# Altium schematic sheet mcu.SchDoc
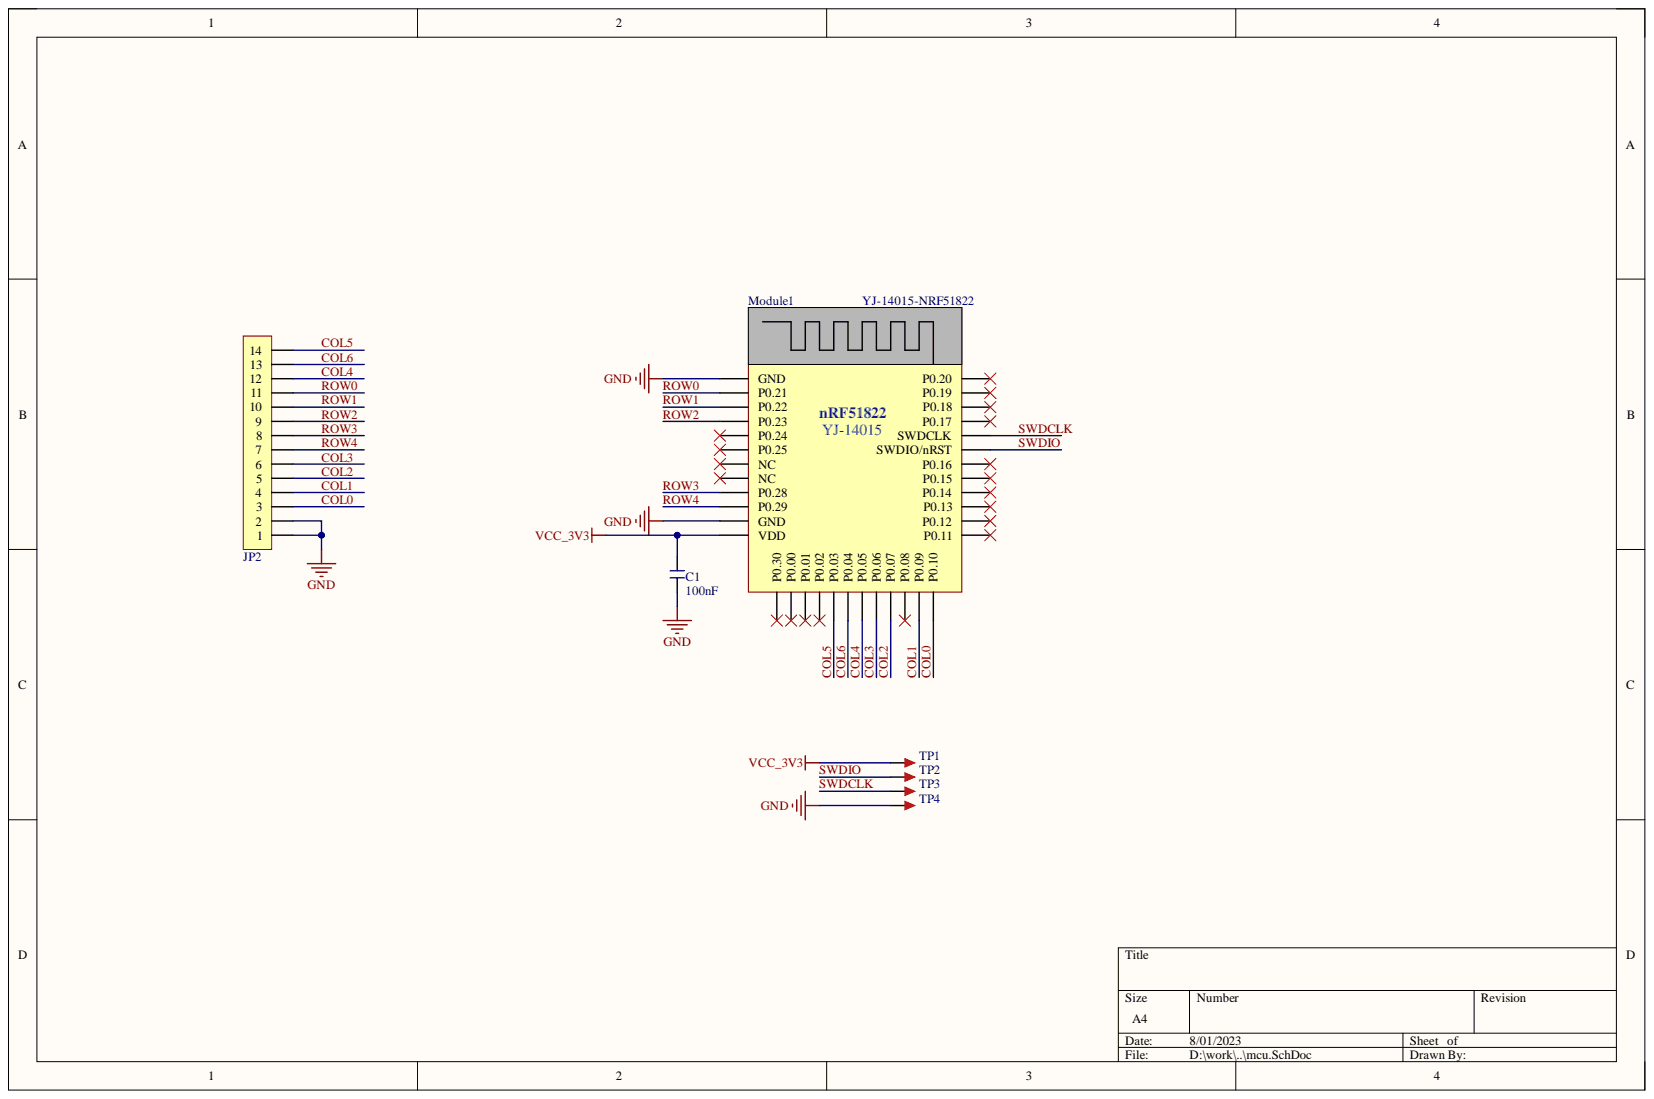
[diagram p0: mcu.SchDoc]

## Parts
C1  100nF  [C 0402]
JP2  FPC1.0-14P  [FPC0.5 2H-S-W-14P]  (value not drawn)
Module1  YJ-14015-NRF51822  [YJ-14015-NRF51822]
TP1  TEST  [TEST 1.8]  (value not drawn)
TP2  TEST  [TEST 1.8]  (value not drawn)
TP3  TEST  [TEST 1.8]  (value not drawn)
TP4  TEST  [TEST 1.8]  (value not drawn)

## Nets
GND: C1.1 JP2.1 JP2.2 Module1.1(GND) Module1.11(GND) TP4.1
VCC_3V3: C1.2 Module1.12(VDD) TP1.1
COL0: JP2.3 Module1.24(P0.10)
COL1: JP2.4 Module1.23(P0.09)
COL2: JP2.5 Module1.21(P0.07)
COL3: JP2.6 Module1.20(P0.06)
COL4: JP2.12 Module1.19(P0.05)
COL5: JP2.14 Module1.17(P0.03)
COL6: JP2.13 Module1.18(P0.04)
ROW0: JP2.11 Module1.2(P0.21)
ROW1: JP2.10 Module1.3(P0.22)
ROW2: JP2.9 Module1.4(P0.23)
ROW3: JP2.8 Module1.9(P0.28)
ROW4: JP2.7 Module1.10(P0.29)
SWDCLK: Module1.32(SWDCLK) TP3.1
SWDIO: Module1.31(SWDIO/nRST) TP2.1

Not connected: Module1 5(P0.24) 6(P0.25) 7(NC) 8(NC) 13(P0.30) 14(P0.00) 15(P0.01) 16(P0.02) 22(P0.08) 25(P0.11) 26(P0.12) 27(P0.13) 28(P0.14) 29(P0.15) 30(P0.16) 33(P0.17) 34(P0.18) 35(P0.19) 36(P0.20)

## Library data of the parts
C1 = C: 无极性贴片电容; 版权归属: 源创客; BOM名称: 无极性贴片电容; Component Kind: Standard; Library Name: 电阻-电容-电感.SchLib; 学习资源: www.ooocke.com
JP2 = FPC-14P: FPC连接器; LC: C262663; 版权归属: 源创客; BOM名称: FPC连接器; Component Kind: Standard; Library Name: FPC连接器.SchLib; 学习资源: www.ooocke.com
TP1-TP4 = TEST: 测试点; 版权归属: 源创客; BOM名称: 测试点; Component Kind: Standard; Library Name: MyPCBLib.SchLib; 学习资源: www.ooocke.com
Module1 = YJ-14015-NRF51822: Component Kind: Standard; Library Name: Modules.SchLib
